# Altium schematic sheet Top.SchDoc
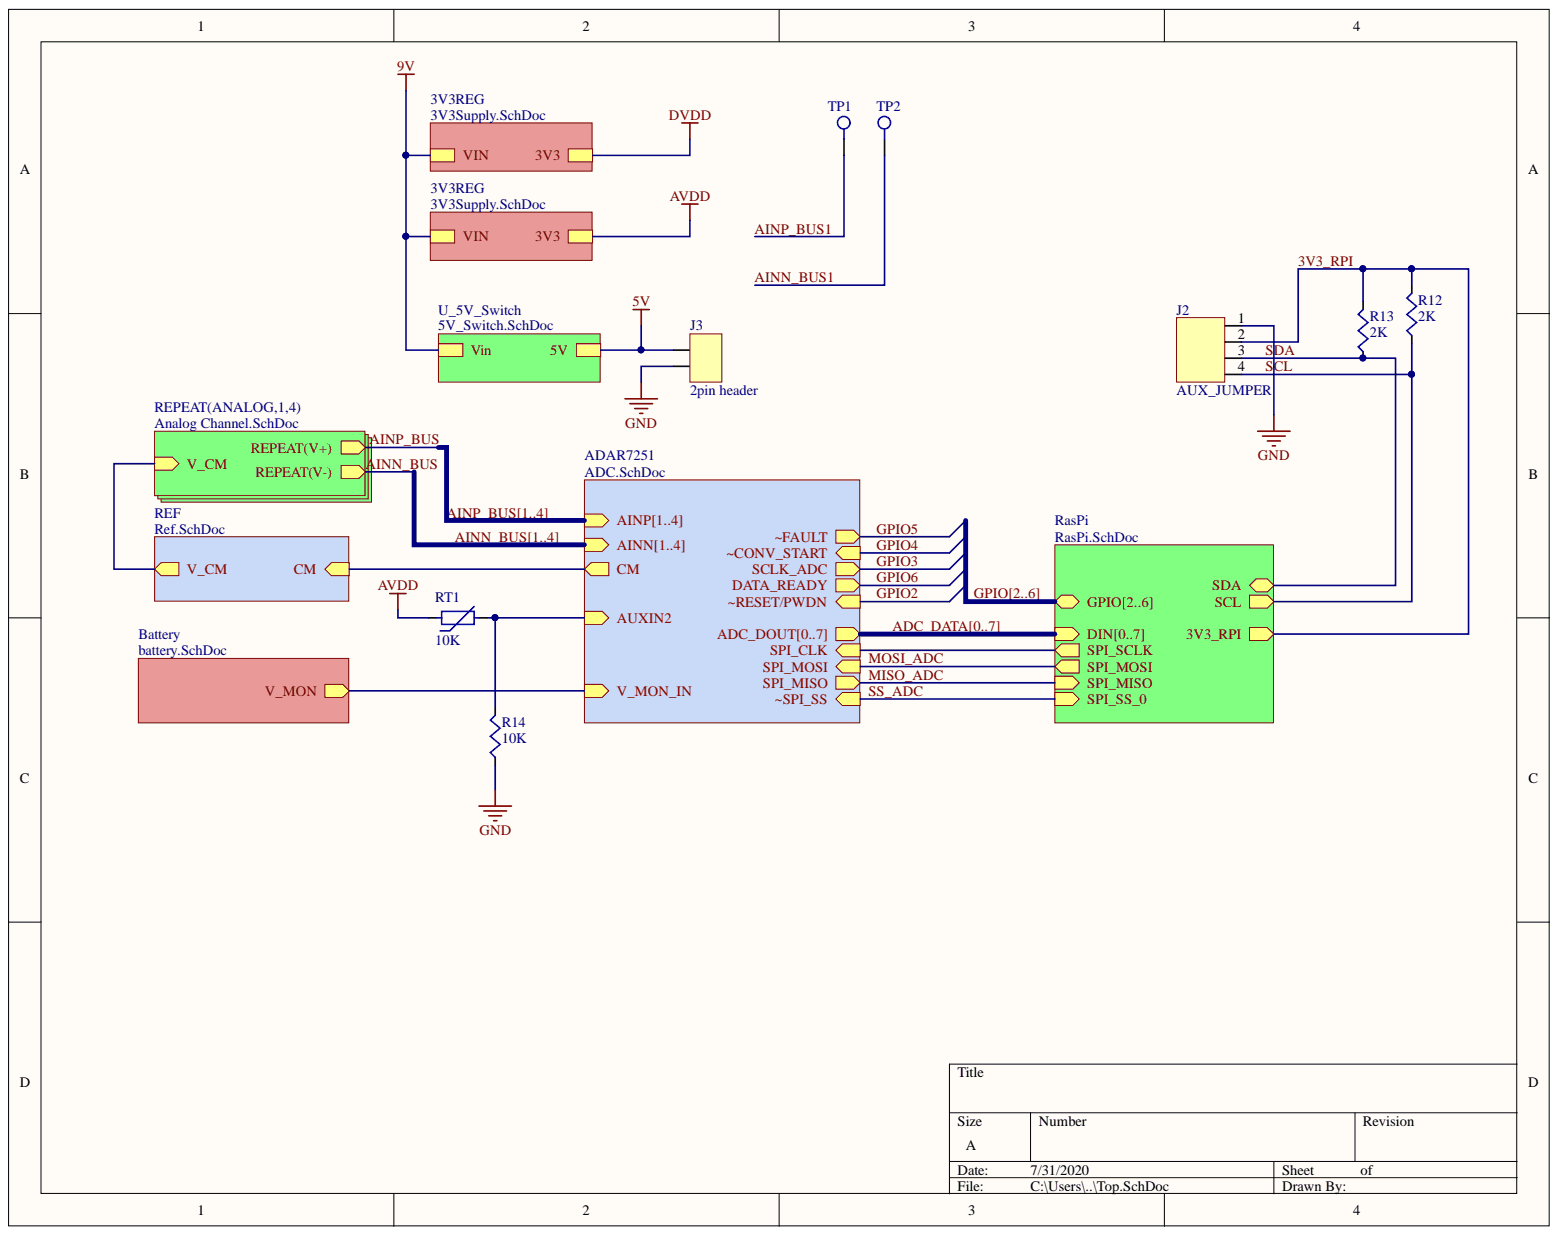
[diagram p0: Top.SchDoc]

## Parts
J2  AUX_JUMPER  [HEADERPINS_2x2]
J3  2pin header  [HEADERPINS_2x1]
R12  2K  [R0603]
R13  2K  [R0603]
R14  10K  [R0603]
RT1  10K  [R0603]
TP1  TP  [TP_5029]
TP2  TP  [TP_5029]

## Nets
5V: J3.2
AVDD: RT1.1
GND: J2.1 J3.1 R14.1
3V3_RPI: J2.2 R12.2 R13.2
AINN_BUS1: TP2.1  [also labelled AINN_BUS]
AINP_BUS1: TP1.1  [also labelled AINP_BUS]
SCL: J2.4 R12.1
SDA: J2.3 R13.1
NetR14_2: R14.2 RT1.2
9V:
ADC_DATA0:  [also labelled ADC_DATA]
ADC_DATA1:  [also labelled ADC_DATA]
ADC_DATA2:  [also labelled ADC_DATA]
ADC_DATA3:  [also labelled ADC_DATA]
ADC_DATA4:  [also labelled ADC_DATA]
ADC_DATA5:  [also labelled ADC_DATA]
ADC_DATA6:  [also labelled ADC_DATA]
ADC_DATA7:  [also labelled ADC_DATA]
AINN_BUS2:  [also labelled AINN_BUS]
AINN_BUS3:  [also labelled AINN_BUS]
AINN_BUS4:  [also labelled AINN_BUS]
AINP_BUS2:  [also labelled AINP_BUS]
AINP_BUS3:  [also labelled AINP_BUS]
AINP_BUS4:  [also labelled AINP_BUS]
CM:
DVDD:
GPIO2:  [also labelled GPIO]
GPIO3:  [also labelled GPIO]
GPIO4:  [also labelled GPIO]
GPIO5:  [also labelled GPIO]
GPIO6:  [also labelled GPIO]
MISO_ADC:
MOSI_ADC:
SPI_CLK:
SS_ADC:
V_CM:
V_MON_IN:

## Library data of the parts
J2 = J4: Component Kind: Standard; Supplier 1: Digi-Key; Supplier Part Number 1: SAM10843-ND; Library Name: DAQ.SchLib
J3 = J2: Component Kind: Standard; Library Name: DAQ.SchLib
R12 R13 = TNPW06032K00BEEA: RES 2K OHM 0.1% 1/8W 0603; Manufacturer 1: Vishay Dale; Max Power Dissipation: 100mW; Resistance: 2kR; Temperature Coefficient: 25ppm/°C; Tolerance: 1%; Voltage Rating: 75V; Voltage Rating (DC): 50V; Case/Package: 0603; Case Code (Imperial): 0603; Case Code (Metric): 1608; Component Kind: Standard; ComponentLink1Description: https://datasheet.ciiva.com/17202/tnpw-e3-17202951.pdf?src-supplier=Avnet+Europe; ComponentLink1URL: https://datasheet.ciiva.com/17202/tnpw-e3-17202951.pdf?src-supplier=Avnet+Europe; ComponentLink2Description: https://datasheet.ciiva.com/28621/1599293-28621623.pdf?src-supplier=Element14; ComponentLink2URL: https://datasheet.ciiva.com/28621/1599293-28621623.pdf?src-supplier=Element14; ComponentLink3Description: https://datasheet.ciiva.com/9094/tnpw-e3-9094713.pdf?src-supplier=Avnet; ComponentLink3URL: https://datasheet.ciiva.com/9094/tnpw-e3-9094713.pdf?src-supplier=Avnet; ComponentLink4Description: https://datasheet.ciiva.com/2483/tnpw-e3-2483322.pdf?src-supplier=Digi-Key; ComponentLink4URL: https://datasheet.ciiva.com/2483/tnpw-e3-2483322.pdf?src-supplier=Digi-Key; ComponentLink5Description: https://datasheet.ciiva.com/2483/tnpw-e3-2483323.pdf?src-supplier=Digi-Key; ComponentLink5URL: https://datasheet.ciiva.com/2483/tnpw-e3-2483323.pdf?src-supplier=Digi-Key; ComponentLink6Description: https://datasheet.ciiva.com/2483/tnpw-e3-2483324.pdf?src-supplier=Digi-Key; ComponentLink6URL: https://datasheet.ciiva.com/2483/tnpw-e3-2483324.pdf?src-supplier=Digi-Key; Composition: Thin Film; Contact Plating: Tin; Depth: 0.85mm; Features: Moisture Resistant,Automotive AEC-Q200,Anti-Sulfur; Height: 0.45mm; Lead Free: Lead Free; Length: 1.6mm; Manufacturer Part Number 1: TNPW06032K00BEEA; Max Operating Temperature: 125°C; Military Standard: Not; Min Operating Temperature: -55°C; Number of Terminations: 2; Package Quantity: 1; Packaging: Tape and Reel; Power Rating: 100mW; Pricing 1: 1=0.65000, 10=0.46200, 100=0.24020, 1000=0.12936, 2500=0.12760 (USD); Radiation Hardening: No; REACH SVHC: Unknown; RoHS: Yes; Stock 1: 36426; Supplier 1: Digi-Key; Supplier Part Number 1: TNP2.00KAACT-ND; Termination: SMD/SMT; Weight: 7.1E-05oz; Width: 0.033inch; Library Name: DAQ.SchLib
R14 = TNPW060310K0BEEA: RES 10K OHM 0.1% 1/8W 0603; Manufacturer 1: Vishay Dale; Max Power Dissipation: 100mW; Resistance: 10kR; Temperature Coefficient: 25ppm/°C; Tolerance: 1%; Voltage Rating: 75V; Case/Package: 0603; Case Code (Imperial): 0603; Case Code (Metric): 1608; Component Kind: Standard; ComponentLink1Description: https://datasheet.ciiva.com/2483/tnpw-e3-2483253.pdf?src-supplier=Digi-Key; ComponentLink1URL: https://datasheet.ciiva.com/2483/tnpw-e3-2483253.pdf?src-supplier=Digi-Key; ComponentLink2Description: https://datasheet.ciiva.com/17190/tnpw-e3-17190917.pdf?src-supplier=Avnet+Europe; ComponentLink2URL: https://datasheet.ciiva.com/17190/tnpw-e3-17190917.pdf?src-supplier=Avnet+Europe; ComponentLink3Description: https://datasheet.ciiva.com/2483/tnpw-e3-2483254.pdf?src-supplier=Digi-Key; ComponentLink3URL: https://datasheet.ciiva.com/2483/tnpw-e3-2483254.pdf?src-supplier=Digi-Key; ComponentLink4Description: https://datasheet.ciiva.com/2483/tnpw-e3-2483255.pdf?src-supplier=Digi-Key; ComponentLink4URL: https://datasheet.ciiva.com/2483/tnpw-e3-2483255.pdf?src-supplier=Digi-Key; ComponentLink5Description: https://datasheet.ciiva.com/16335/tnpw-e3-16335132.pdf?src-supplier=Future+Electronics; ComponentLink5URL: https://datasheet.ciiva.com/16335/tnpw-e3-16335132.pdf?src-supplier=Future+Electronics; ComponentLink6Description: https://datasheet.ciiva.com/28589/1912606-28589004.pdf?src-supplier=Element14; ComponentLink6URL: https://datasheet.ciiva.com/28589/1912606-28589004.pdf?src-supplier=Element14; ComponentLink7Description: https://datasheet.ciiva.com/7792/tnpw-e3-7792477.pdf?src-supplier=Element14; ComponentLink7URL: https://datasheet.ciiva.com/7792/tnpw-e3-7792477.pdf?src-supplier=Element14; ComponentLink8Description: https://datasheet.ciiva.com/9050/tnpw-e3-9050974.pdf?src-supplier=Avnet; ComponentLink8URL: https://datasheet.ciiva.com/9050/tnpw-e3-9050974.pdf?src-supplier=Avnet; Composition: Thin Film; Contact Plating: Tin; Depth: 0.85mm; Features: Moisture Resistant,Automotive AEC-Q200,Anti-Sulfur; Height: 0.022inch; Length: 1.6mm; Manufacturer Part Number 1: TNPW060310K0BEEA; Max Operating Temperature: 125°C; Military Standard: Not; Min Operating Temperature: -55°C; Mount: Surface Mount; Number of Terminations: 2; Package Quantity: 1; Packaging: Tape and Reel; Power Rating: 100mW; Pricing 1: 1=0.65000, 10=0.46200, 100=0.24020, 1000=0.12936, 2500=0.12760 (USD); Radiation Hardening: No; REACH SVHC: Unknown; RoHS: Yes; Stock 1: 296181; Supplier 1: Digi-Key; Supplier Part Number 1: TNP10.0KAACT-ND; Termination: SMD/SMT; Weight: 7.1E-05oz; Width: 0.033inch; Library Name: DAQ.SchLib
RT1 = ERT-J1VG103JA: Thermistor NTC 10KOhm 5% 2-Pin 0603 Punched Carrier T/R; Manufacturer 1: Panasonic; Accuracy: 1%; Max Power Dissipation: 100mW; Resistance: 10kR; Case/Package: 0603; Case Code (Imperial): 0603; Case Code (Metric): 1608; Component Kind: Standard; ComponentLink1Description: https://datasheet.ciiva.com/1303/1314428-1303168.pdf?src-supplier=Farnell; ComponentLink1URL: https://datasheet.ciiva.com/1303/1314428-1303168.pdf?src-supplier=Farnell; ComponentLink2Description: https://datasheet.ciiva.com/30067/aua0000ce1-30067157.pdf?src-supplier=Onlinecomponents.com; ComponentLink2URL: https://datasheet.ciiva.com/30067/aua0000ce1-30067157.pdf?src-supplier=Onlinecomponents.com; ComponentLink3Description: https://datasheet.ciiva.com/46376/1314428-46376550.pdf?src-supplier=Element14; ComponentLink3URL: https://datasheet.ciiva.com/46376/1314428-46376550.pdf?src-supplier=Element14; ComponentLink4Description: https://datasheet.ciiva.com/2848/aua0000ce1-2848556.pdf?src-supplier=Digi-Key; ComponentLink4URL: https://datasheet.ciiva.com/2848/aua0000ce1-2848556.pdf?src-supplier=Digi-Key; ComponentLink5Description: https://datasheet.ciiva.com/16394/aua0000ce1-16394028.pdf?src-supplier=Future+Electronics; ComponentLink5URL: https://datasheet.ciiva.com/16394/aua0000ce1-16394028.pdf?src-supplier=Future+Electronics; ComponentLink6Description: https://datasheet.ciiva.com/46388/1314428-46388812.pdf?src-supplier=Element14; ComponentLink6URL: https://datasheet.ciiva.com/46388/1314428-46388812.pdf?src-supplier=Element14; ComponentLink7Description: https://datasheet.ciiva.com/2848/aua0000ce1-2848557.pdf?src-supplier=Digi-Key; ComponentLink7URL: https://datasheet.ciiva.com/2848/aua0000ce1-2848557.pdf?src-supplier=Digi-Key; ComponentLink8Description: https://datasheet.ciiva.com/2848/aua0000ce1-2848558.pdf?src-supplier=Digi-Key; ComponentLink8URL: https://datasheet.ciiva.com/2848/aua0000ce1-2848558.pdf?src-supplier=Digi-Key; ComponentLink9Description: https://datasheet.ciiva.com/3280/aua0000ce1-3280462.pdf?src-supplier=Avnet; ComponentLink9URL: https://datasheet.ciiva.com/3280/aua0000ce1-3280462.pdf?src-supplier=Avnet; Depth: 0.8mm; Lead Free: Lead Free; Length: 1.6mm; Manufacturer Part Number 1: ERT-J1VG103JA; Max Operating Temperature: 125°C; Min Operating Temperature: -40°C; Mount: Surface Mount; Number of Pins: 2; Package Quantity: 4000; Packaging: Tape and Reel; Pricing 1: 1=0.15000, 5=0.14600, 10=0.13000, 25=0.12000, 50=0.09600, 100=0.08240, 500=0.07208, 1000=0.06178 (USD); Radiation Hardening: No; REACH SVHC: No SVHC; RoHS: Yes; Stock 1: 47318; Supplier 1: Digi-Key; Supplier Part Number 1: P12014CT-ND; Termination: SMD/SMT; Tolerance: 5%; Width: 800um; Library Name: DAQ.SchLib
TP1 TP2 = TP: 5029 SMD 0805 TP; Component Kind: Standard; Supplier 1: Digi-Key; Supplier Part Number 1: 36-5029CT-ND; Library Name: DAQ.SchLib
